# Altium schematic sheet IO.SchDoc
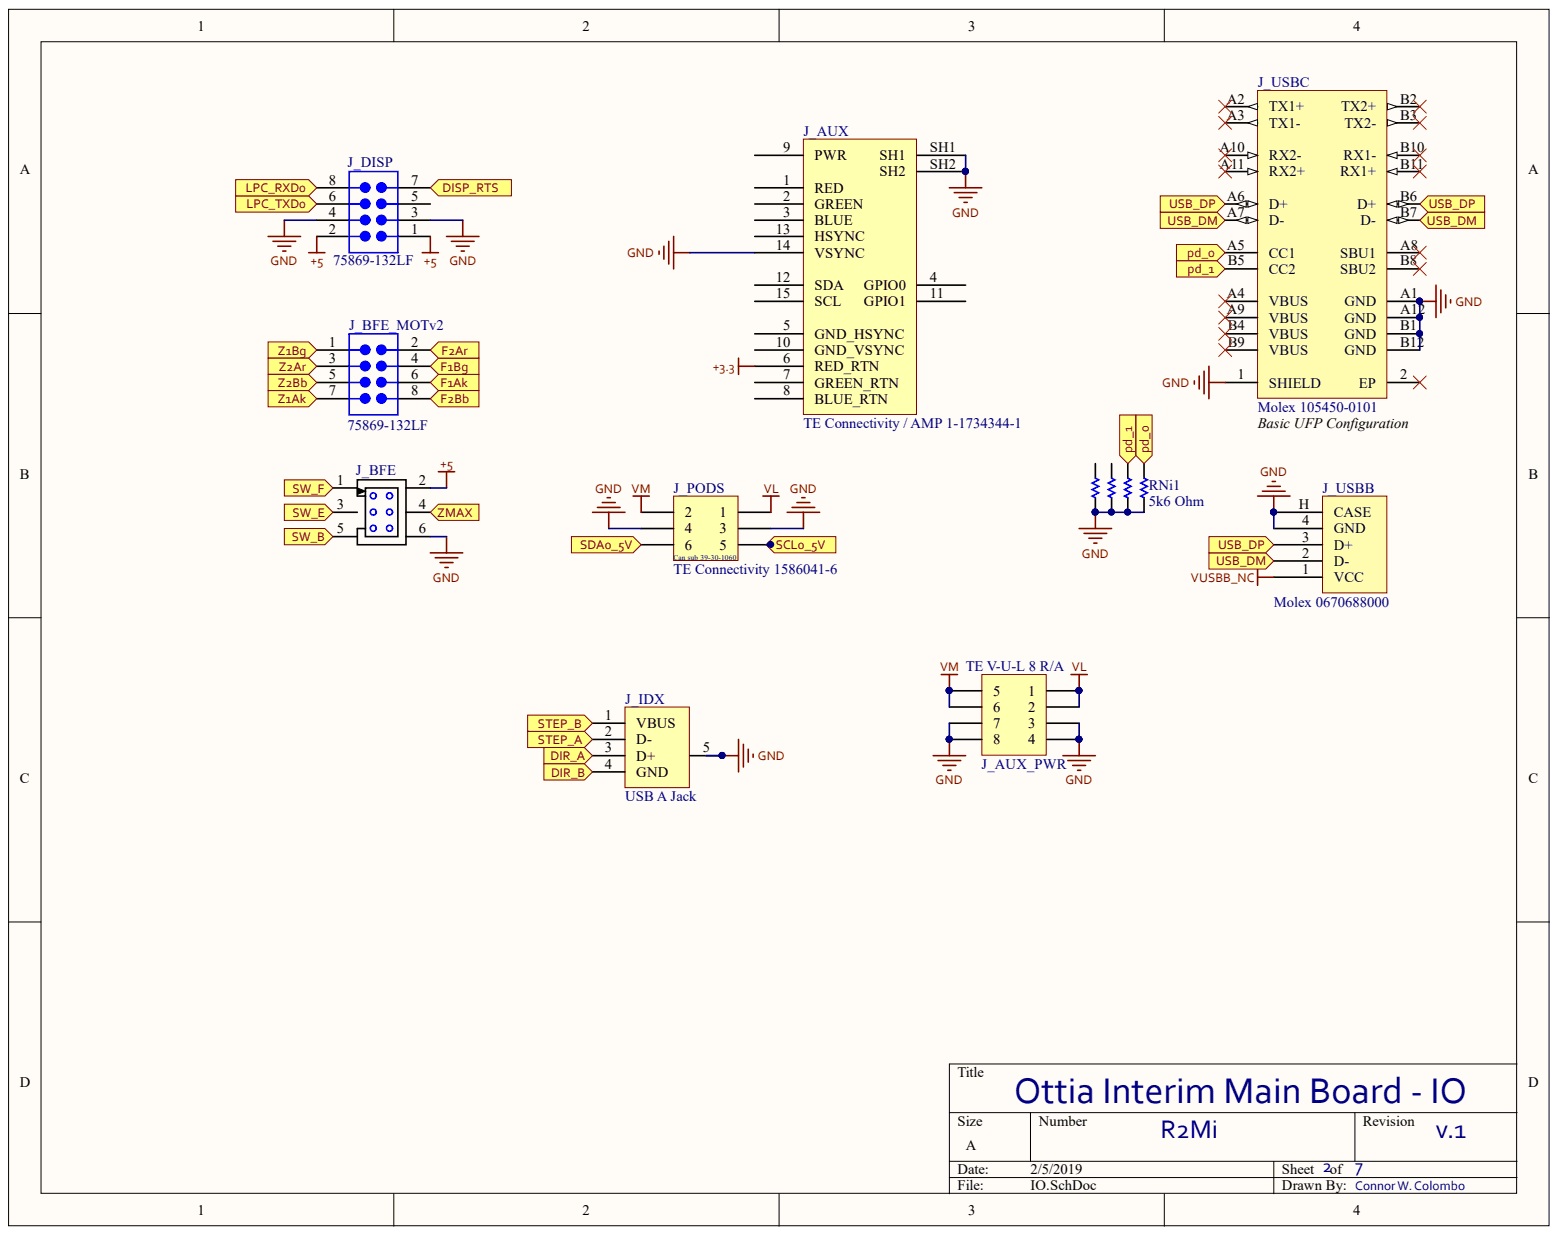
[diagram p0: IO.SchDoc]

## Parts
J_AUX  TE Connectivity / AMP 1-1734344-1  Manufacturer Part Number: 1-1734344-1  [PCB-gyvqbeqvcmymul9qatyf-1]
J_AUX_PWR  TE V-U-L 8 R/A  [PCB-4gad0ww5nj16sz0n7wsq-1]
J_BFE  FCI 75869-131LF  [PCB-l6fbhhbmmdzifrvjozvs-1]  (value not drawn)
J_BFE_MOTv2  75869-132LF  [IDC-8_L]
J_DISP  75869-132LF  [IDC-8_L]
J_IDX  USB A Jack  [USB_A_SH]
J_PODS  TE Connectivity 1586041-6  Manufacturer Part Number: 1586041-6  [PCB-6r85ft6ouezw7zgfi4qe-1]
J_USBB  Molex 0670688000  Manufacturer Part Number: 0670688000  [PCB-wpveznbn3212ogwgv27g-1]
J_USBC  Molex 105450-0101  [PCB-zb4thd4mh8xiiqufiqw2-1]
RNi1  5k6 Ohm  [PCB-1201p24ncc8e2pnsi1d0-1]

## Ports
DIR_A, DIR_B, DISP_RTS, F1AK, F1BG, F2AR, F2BB, LPC_RXD0, LPC_TXD0, PD_0, PD_1, SCL0_5V, SDA0_5V, STEP_A, STEP_B, SW_B, SW_E, SW_F, USB_DM, USB_DP, Z1AK, Z1BG, Z2AR, Z2BB, ZMAX

## Nets
+3.3: J_AUX.6(RED_RTN)
+5: J_BFE.2 J_DISP.1 J_DISP.2
GND: J_AUX.14(VSYNC) J_AUX.SH1 J_AUX.SH2 J_AUX_PWR.3 J_AUX_PWR.4 J_AUX_PWR.7 J_AUX_PWR.8 J_BFE.6 J_DISP.3 J_DISP.4 J_IDX.5 J_PODS.3 J_PODS.4 J_USBB.4(GND) J_USBB.H(CASE) J_USBC.1(SHIELD) J_USBC.A1(GND) J_USBC.A12(GND) J_USBC.B1(GND) J_USBC.B12(GND) RNi1.5 RNi1.6 RNi1.7 RNi1.8
VL: J_AUX_PWR.1 J_AUX_PWR.2 J_PODS.1
VM: J_AUX_PWR.5 J_AUX_PWR.6 J_PODS.2
VUSBB_NC: J_USBB.1(VCC)
DIR_A: J_IDX.3(D+) ; port DIR_A
DIR_B: J_IDX.4(GND) ; port DIR_B
DISP_RTS: J_DISP.7 ; port DISP_RTS
LPC_RXD0: J_DISP.8 ; port LPC_RXD0
LPC_TXD0: J_DISP.6 ; port LPC_TXD0
SCL0_5V: J_PODS.5 ; port SCL0_5V
SDA0_5V: J_PODS.6 ; port SDA0_5V
STEP_A: J_IDX.2(D-) ; port STEP_A
STEP_B: J_IDX.1(VBUS) ; port STEP_B
SW_B: J_BFE.5 ; port SW_B
SW_E: J_BFE.3 ; port SW_E
SW_F: J_BFE.1 ; port SW_F
USB_DM: J_USBB.2(D-) J_USBC.A7(D-) J_USBC.B7(D-) ; port USB_DM
USB_DP: J_USBB.3(D+) J_USBC.A6(D+) J_USBC.B6(D+) ; port USB_DP
ZMAX: J_BFE.4 ; port ZMAX
NetJ_BFE_MOTv2_1: J_BFE_MOTv2.1 ; port Z1BG
NetJ_BFE_MOTv2_2: J_BFE_MOTv2.2 ; port F2AR
NetJ_BFE_MOTv2_3: J_BFE_MOTv2.3 ; port Z2AR
NetJ_BFE_MOTv2_4: J_BFE_MOTv2.4 ; port F1BG
NetJ_BFE_MOTv2_5: J_BFE_MOTv2.5 ; port Z2BB
NetJ_BFE_MOTv2_6: J_BFE_MOTv2.6 ; port F1AK
NetJ_BFE_MOTv2_7: J_BFE_MOTv2.7 ; port Z1AK
NetJ_BFE_MOTv2_8: J_BFE_MOTv2.8 ; port F2BB
NetJ_USBC_A5: J_USBC.A5(CC1) RNi1.1 ; port PD_0
NetJ_USBC_B5: J_USBC.B5(CC2) RNi1.2 ; port PD_1

Not connected: J_AUX 1(RED) 2(GREEN) 3(BLUE) 4(GPIO0) 5(GND_HSYNC) 7(GREEN_RTN) 8(BLUE_RTN) 9(PWR) 10(GND_VSYNC) 11(GPIO1) 12(SDA) 13(HSYNC) 15(SCL); J_DISP 5; J_USBC 2(EP) A2(TX1+) A3(TX1-) A4(VBUS) A8(SBU1) A9(VBUS) A10(RX2-) A11(RX2+) B2(TX2+) B3(TX2-) B4(VBUS) B8(SBU2) B9(VBUS) B10(RX1-) B11(RX1+); RNi1 3 4

## Library data of the parts
J_AUX = CMP-5ecd95ac17d0810a-4: Conn High Density D-Sub SKT 15 POS 2.29mm Solder RA Thru-Hole 15 Terminal 1 Port Automotive Tray; ELV Compliant: Compliant; Color: Blue; Component Kind: Standard; Contact Material: Phosphor Bronze; Contact Plating: Gold Flash, Tin over Nickel; Flammability Rating: UL 94 V-0; Housing Color: Blue; Housing Material: Thermoplastic; Library Name: CommunityVault; Lifecycle Status: Active; Mounting Style: Through Hole; OctopartID: 5ecd95ac17d0810a; Orientation: Right Angle; Packaging: Tray, Box; RoHS: Compliant
J_AUX_PWR = CMP-0617cd461937d9d0-2: Conn Power PIN 8 POS 4.2mm Solder RA Thru-Hole 8 Terminal 1 Port Box/Tray; ELV Compliant: Compliant; Gender: Male; Insulation Resistance: 1.00 GΩ; Mounting Angle: 90.0 °; Number of Contacts: 8; Number of Mating Cycles: 50; Voltage Rating (AC): 600 V; Color: White; Component Kind: Standard; Contact Material: Brass; Housing Color: Natural; Housing Material: Nylon; Library Name: CommunityVault; Number of Positions: 8; Number of Rows: 2; OctopartID: 0617cd461937d9d0; Octopart URL: https://octopart.com/1586041-8-te+connectivity-39533126; Orientation: Right Angle; Packaging: Tray, Box; RoHS: Compliant; Size-Width: 12.8 mm
J_BFE = 75869-131LF: CONN HEADER 6POS DUAL VERT PCB; Gender: Male; Lead-Free Status: Lead Free; Number of Contacts: 6; Component Kind: Standard; Contact Material: Phosphor Bronze; Contact Plating: Gold; Library Name: Octopart library; OctopartID: 9939526fc2f646fe; Octopart Url: https://octopart.com/75869-131lf-fci-39616169; REACH SVHC Compliance: No SVHC; RoHS: Compliant
J_BFE_MOTv2 J_DISP = 75869-132LF: Conn; Rect; Header; 8Cnts; ThruMount; Quickie; 2.54mmPin-Spng; Vert; DblRow; Gender: Male; Lead-Free Status: Lead Free; Number of Contacts: 8; Component Kind: Standard; Contact Material: Phosphor Bronze; Contact Plating: Gold; Library Name: Octopart library; Manufacturer Part Number: 75869-132LF; Mounting Style: Through Hole; OctopartID: 96fb5d93ea7f493c; Octopart URL: http://octopart.com/75869-132lf-fci-39999970; RoHS: Compliant
J_IDX = 87520-0010BLF: Conn; Data; USB; TypeA; Socket; 4Cnts; RightAngle; ThruHole; White; 1.57mmPCB; Component Kind: Standard; Library Name: Octopart library
J_PODS = CMP-2a79e1b6b09df5be-1: Conn Power HDR 6 POS 4.2mm Solder RA Thru-Hole 6 Terminal 1 Port Tray; Current Rating: 9.00 A; ELV Compliant: Compliant; Gender: Male; Insulation Resistance: 1.00 G?; Lead-Free Status: Lead Free; Mounting Angle: 90.0 °; Number of Circuits: 6; Number of Mating Cycles: 50; Pin Pitch: 4.20 mm; Voltage Rating (AC): 600 V; Color: White; Component Kind: Standard; Contact Material: Brass; Contact Plating: Tin; Flammability Rating: UL 94 V-2; Housing Color: Natural; Housing Material: Nylon; Insulation Material: Nylon; Library Name: FavoritesLibrary; Lifecycle Status: Active; Mounting Style: Solder, Through Hole; Number of Contacts: 6; Number of Positions: 6; Number of Rows: 2; OctopartID: 2a79e1b6b09df5be; Octopart URL: https://octopart.com/1586041-6-te+connectivity-46514050; Operating Temperature: -40.0 °C to 105 °C; Orientation: Right Angle; Packaging: Tray, Box; REACH SVHC Compliance: No SVHC; RoHS: Compliant; Size-Width: 12.8 mm
J_USBB = 67068-8000: Conn USB Type B RCP 4 POS 2.5mm Solder RA Thru-Hole 4 Terminal 1 Port Tray; Component Kind: Standard; Library Name: Octopart library; Octopart URL: http://octopart.com/0670688000-molex-11705424; Orientation: Right Angle
J_USBC = 105450-0101: USB Shielded I/O Receptacle; Type C; Right Angle; Surface Mount; Gold over Nickel; Gender: Female; Component Kind: Standard; Library Name: Octopart library; Mounting Style: Surface Mount; Octopart URL: http://octopart.com/105450-0101-molex-68082325; Orientation: Right Angle
RNi1 = CMP-0aeac3f5d3eed52d-1: Res Thick Film Array 5.6K Ohm 5% ±200ppm/°C ISOL Molded 8-Pin 1206(4 X 0603) Convex SMD Paper T/R; Manufacturer Part Number: YC164-JR-075K6L; Lead-Free Status: Lead Free; Number of Circuits: 4; Number of Contacts: 8; Resistance: 5.60 kΩ; Voltage Rating (DC): 50.0 V; Case/Package: 0612; Component Kind: Standard; Library Name: CommunityVault; Mounting Style: Surface Mount; OctopartID: 0aeac3f5d3eed52d; Octopart URL: http://octopart.com/yc164-jr-075k6l-yageo-1440866; Resistance Tolerance: ±5%; RoHS: Compliant; Size-Height: 600 µm; Size-Length: 3.20 mm; Size-Width: 1.60 mm
